# Altium schematic sheet ECU_Power.SchDoc
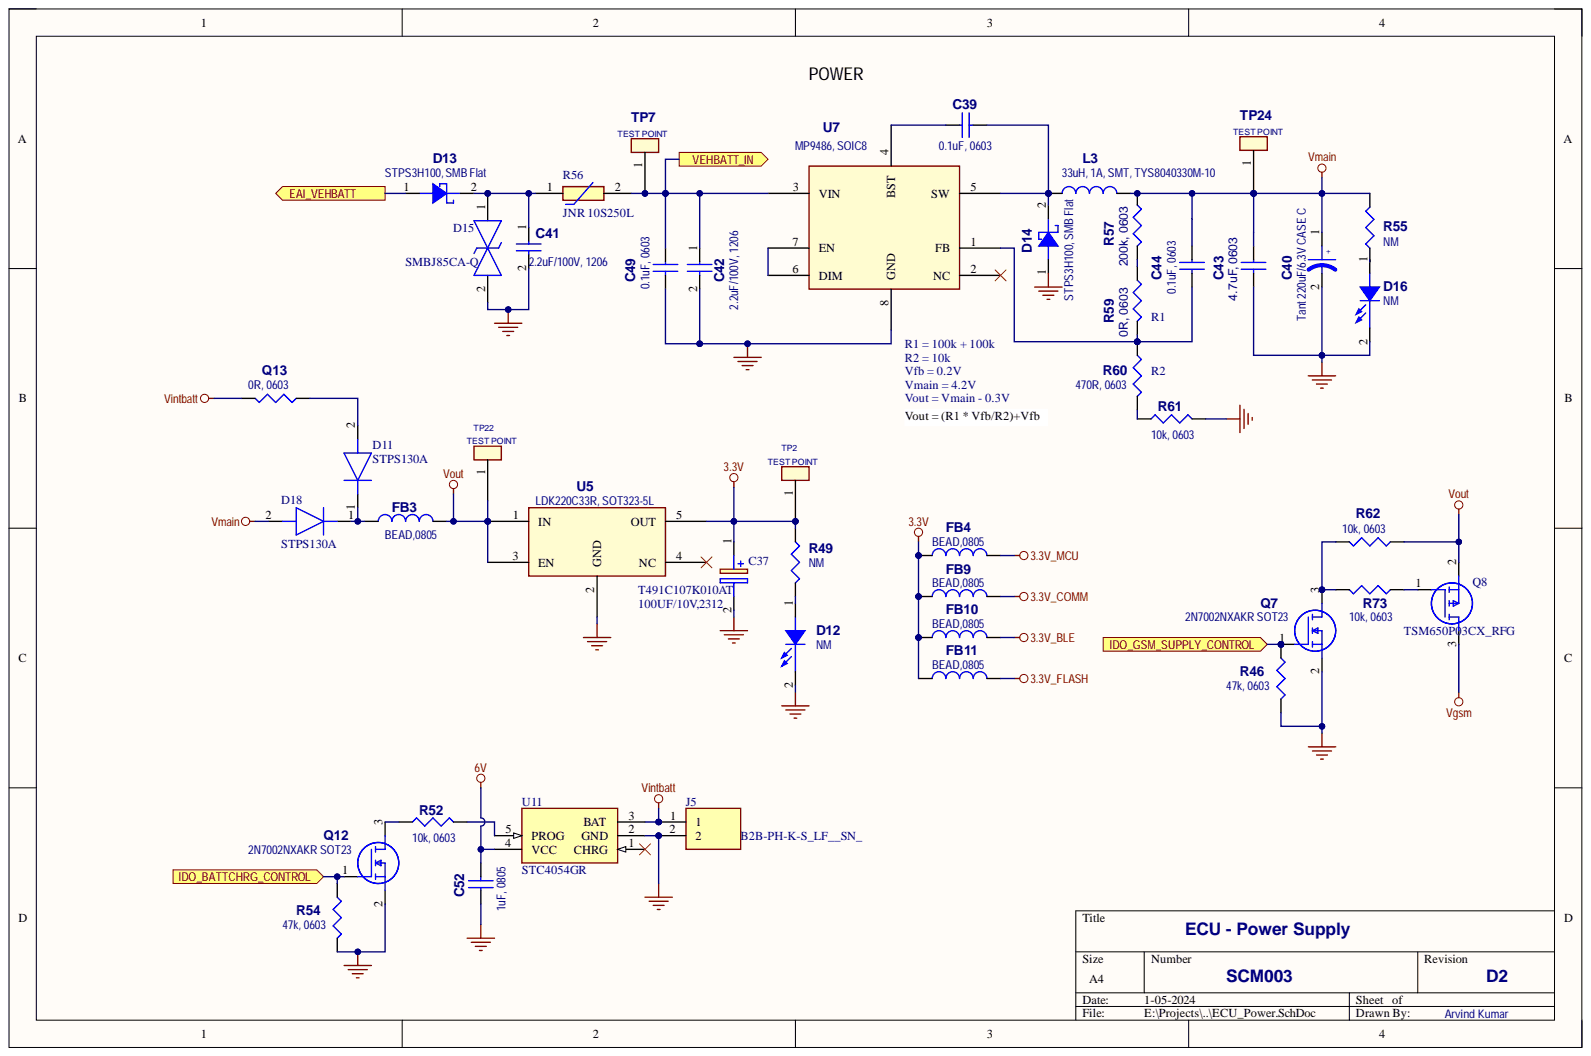
[diagram p0: ECU_Power.SchDoc]

## Parts
C37  T491C107K010AT  [T491C]
C39  0.1uF, 0603  [C0603]
C40  Tant 220uF/6.3V CASE C  [C19............CAP_TANT_2412-C_case]
C41  2.2uF/100V, 1206  [C20, C21..........C1206]
C42  2.2uF/100V, 1206  [C20, C21..........C1206]
C43  4.7uF, 0603  [C0603]
C44  0.1uF, 0603  [C0603]
C49  0.1uF, 0603  [C0603]
C52  1uF, 0805  [C0805]
D11  STPS130A  [STPS130A]
D12  NM  [LED0603]
D13  STPS3H100, SMB Flat  [STPS3H100]
D14  STPS3H100, SMB Flat  [STPS3H100]
D15  SMBJ85CA-Q  [DIONM5436X244N]
D16  NM  [LED0603]
D18  STPS130A  [STPS130A]
FB3  BEAD,0805  [C0805]
FB4  BEAD,0805  [C0805]
FB9  BEAD,0805  [C0805]
FB10  BEAD,0805  [C0805]
FB11  BEAD,0805  [C0805]
J5  B2B-PH-K-S_LF__SN_  [SHDR2W50P0X200_1X2_590X450X600P]
L3  33uH, 1A, SMT, TYS8040330M-10  [330UH]
Q7  2N7002NXAKR SOT23  [2N7002NXAKR]
Q8  TSM650P03CX_RFG  [SOT95P280X110-3N]
Q12  2N7002NXAKR SOT23  [2N7002NXAKR]
Q13  0R, 0603  [R0603]
R46  47k, 0603  [R0603]
R49  NM  [R0603]
R52  10k, 0603  [R0603]
R54  47k, 0603  [R0603]
R55  NM  [R0603]
R56  JNR 10S250L  [B57153S0***M0**]
R57  200k, 0603  [R0603]
R59  0R, 0603  [R0603]
R60  470R, 0603  [R0603]
R61  10k, 0603  [R0603]
R62  10k, 0603  [R0603]
R73  10k, 0603  [R0603]
TP2  TEST POINT  [Test Point SMD 1.5mm]
TP7  TEST POINT  [Test Point SMD 1.5mm]
TP22  TEST POINT  [Test Point]
TP24  TEST POINT  [Test Point]
U5  LDK220C33R, SOT323-5L  [LDK220C33R, SOT323-5L]
U7  MP9486, SOIC8  [MP9486]
U11  STC4054GR  [SOT95P280X110-5N]

## Ports
EAI_VEHBATT, IDO_BATTCHRG_CONTROL, IDO_GSM_SUPPLY_CONTROL, VEHBATT_IN

## Nets
3.3V: C37.1 FB4.1 FB9.1 FB10.1 FB11.1 R49.2 TP2.1 U5.5(OUT)
3.3V_BLE: FB10.2
3.3V_COMM: FB9.2
3.3V_FLASH: FB11.2
3.3V_MCU: FB4.2
6V: C52.2 U11.4(VCC)
GND_POWER: C37.2 C40.2 C41.2 C42.2 C43.2 C49.2 C52.1 D12.2 D14.1 D15.2 D16.2 J5.2 Q7.2 Q12.2 R46.2 R54.2 R61.2 U5.2(GND) U7.8(GND) U11.2(GND)
Vgsm: Q8.3
Vintbatt: J5.1 Q13.2 U11.3(BAT)
Vmain: C40.1 C43.1 C44.1 D18.2 L3.2 R55.1 R57.1 TP24.1
Vout: FB3.2 Q8.2 R62.1 TP22.1 U5.1(IN) U5.3(EN)
EAI_VEHBATT: D13.1 ; port EAI_VEHBATT
IDO_BATTCHRG_CONTROL: Q12.1 R54.1 ; port IDO_BATTCHRG_CONTROL
IDO_GSM_SUPPLY_CONTROL: Q7.1 R46.1 ; port IDO_GSM_SUPPLY_CONTROL
VEHBATT_IN: C42.1 C49.1 R56.2 TP7.1 U7.3(VIN) ; port VEHBATT_IN
NetC39_1: C39.1 U7.4(BST)
NetC39_2: C39.2 D14.2 L3.1 U7.5(SW)
NetC41_1: C41.1 D13.2 D15.1 R56.1
NetC44_2: C44.2 R59.2 R60.1 U7.1(FB)
NetD11_1: D11.1 D18.1 FB3.1
NetD11_2: D11.2 Q13.1
NetD12_1: D12.1 R49.1
NetD16_1: D16.1 R55.2
NetQ7_3: Q7.3 R62.2 R73.2
NetQ8_1: Q8.1 R73.1
NetQ12_3: Q12.3 R52.1
NetR52_2: R52.2 U11.5(PROG)
NetR57_2: R57.2 R59.1
NetR60_2: R60.2 R61.1
NetU7_6: U7.6(DIM) U7.7(EN)

Not connected: U5 4(NC); U7 2(NC); U11 1(CHRG)

## Library data of the parts
C37 = T491C107K010AT: Capacitor Polarised; Manufacturer_Name: KEMET; Arrow Part Number: T491C107K010AT; Arrow Price/Stock: https://www.arrow.com/en/products/t491c107k010at/kemet-corporation?region=nac; Component Kind: Standard; Datasheet Link: https://content.kemet.com/datasheets/KEM_T2005_T491.pdf; Height: 2.8mm; Manufacturer_Part_Number: T491C107K010AT; Mouser Part Number: 80-T491C107K010; Mouser Price/Stock: https://www.mouser.co.uk/ProductDetail/KEMET/T491C107K010AT?qs=qfhfvpM3tgjUG2DdB%2FXhNw%3D%3D
C39 C41-C44 C49 = CAP NP_Dup2: Component Kind: Standard
C40 = CAP ELEC: Component Kind: Standard
C52 = CAP NP: Component Kind: Standard
D11 D18 = STPS130A: Diode; Manufacturer_Name: STMicroelectronics; Arrow Part Number: STPS130A; Arrow Price/Stock: https://www.arrow.com/en/products/stps130a/stmicroelectronics?region=nac; Component Kind: Standard; Datasheet Link: http://www.st.com/content/ccc/resource/technical/document/datasheet/0e/c7/47/79/e6/03/4e/07/CD00000992.pdf/files/CD00000992.pdf/jcr:content/translations/en.CD00000992.pdf; Height: 2.65mm; Manufacturer_Part_Number: STPS130A; Mouser Part Number: 511-STPS130A; Mouser Price/Stock: https://www.mouser.co.uk/ProductDetail/STMicroelectronics/STPS130A?qs=K4DpzJ20gDGDj86tgje4WA%3D%3D
D12 D16 = LED: Component Kind: Standard
D13 D14 = DIODE SCHOTTKY: Component Kind: Standard
D15 = SMBJ85CA-Q: TVS Diode (Bi-directional); Manufacturer_Name: Bourns; Component Kind: Standard; Datasheet Link: https://www.bourns.com/docs/Product-Datasheets/SMBJ-Q.pdf; Height: 2.44mm; Manufacturer_Part_Number: SMBJ85CA-Q
FB3 FB4 FB9-FB11 L3 = INDUCTOR: Component Kind: Standard
J5 = B2B-PH-K-S_LF__SN_: Connector; Manufacturer_Name: JST (JAPAN SOLDERLESS TERMINALS); Manufacturer_Part_Number: B2B-PH-K-S(LF)(SN); Arrow Part Number: B2B-PH-K-S(LF)(SN); Arrow Price/Stock: https://www.arrow.com/en/products/b2b-ph-k-s-lf-sn/jst-manufacturing; Component Kind: Standard; Datasheet Link: https://datasheet.lcsc.com/szlcsc/JST-Sales-America-B2B-PH-K-S-LF-SN_C131337.pdf; Height: 6mm
Q7 Q12 = BSS138: MOSFET (N-Channel); Manufacturer_Name: ON Semiconductor; Arrow Part Number: BSS138; Arrow Price/Stock: https://www.arrow.com/en/products/bss138/on-semiconductor; Component Kind: Standard; Datasheet Link: https://www.onsemi.com/pub/Collateral/BSS138-D.PDF; Height: 1.2mm; Library Name: SamacSys.SchLib; Manufacturer_Part_Number: BSS138; Mouser Part Number: 512-BSS138; Mouser Price/Stock: https://www.mouser.co.uk/ProductDetail/ON-Semiconductor-Fairchild/BSS138?qs=HK%252BeIG1iaahCeqBvjB4arg%3D%3D
Q8 = TSM650P03CX_RFG: MOSFET (P-Channel); Manufacturer_Name: Taiwan Semiconductor; Manufacturer_Part_Number: TSM650P03CX RFG; Component Kind: Standard; Datasheet Link: https://www.taiwansemi.com/assets/uploads/datasheet/TSM650P03CX_B1811.pdf; Height: 1.1mm; Mouser Part Number: 821-TSM650P03CXRFG; Mouser Price/Stock: https://www.mouser.co.uk/ProductDetail/Taiwan-Semiconductor/TSM650P03CX-RFG?qs=5aG0NVq1C4zF8Oh9PJmPGA%3D%3D
Q13 R46 R54 R62 R73 = R: Component Kind: Standard
R49 R55 = RESISTOR: Component Kind: Standard
R52 R57 R59-R61 = R_Dup1: Component Kind: Standard
R56 = B57153S0479M000: Thermistor; Manufacturer_Name: TDK; Arrow Part Number: B57153S0479M000; Arrow Price/Stock: https://www.arrow.com/en/products/b57153s0479m000/epcos-tdk; Component Kind: Standard; Datasheet Link: https://product.tdk.com/system/files/dam/doc/product/protection/current/ntc-limiter/data_sheet/50/db/icl_16/s153.pdf; Height: 13mm; Manufacturer_Part_Number: B57153S0479M000; Mouser Part Number: 871-B57153S0479M000; Mouser Price/Stock: https://www.mouser.co.uk/ProductDetail/EPCOS-TDK/B57153S0479M000?qs=AKDv8POSxR3K5KtonuvMKw%3D%3D
U5 = LDK220C33R: Component Kind: Standard
U7 = MP9486: Component Kind: Standard
U11 = STC4054GR: Integrated Circuit; Manufacturer_Name: STMicroelectronics; Arrow Part Number: STC4054GR; Arrow Price/Stock: https://www.arrow.com/en/products/stc4054gr/stmicroelectronics?region=nac; Component Kind: Standard; Datasheet Link: https://componentsearchengine.com/Datasheets/2/STC4054GR.pdf; Height: 1.1mm; Library Name: ECU_SCHLib.SchLib; Manufacturer_Part_Number: STC4054GR; Mouser Part Number: 511-STC4054GR; Mouser Price/Stock: https://www.mouser.co.uk/ProductDetail/STMicroelectronics/STC4054GR?qs=%252BFmDY%2FmH7Ib7AgzA73nDoQ%3D%3D
TP2 TP7 TP22 TP24 = TEST POINT: Component Kind: Standard
